# Altium schematic sheet 7.QMC5883L.SchDoc(7.QMC5883L)
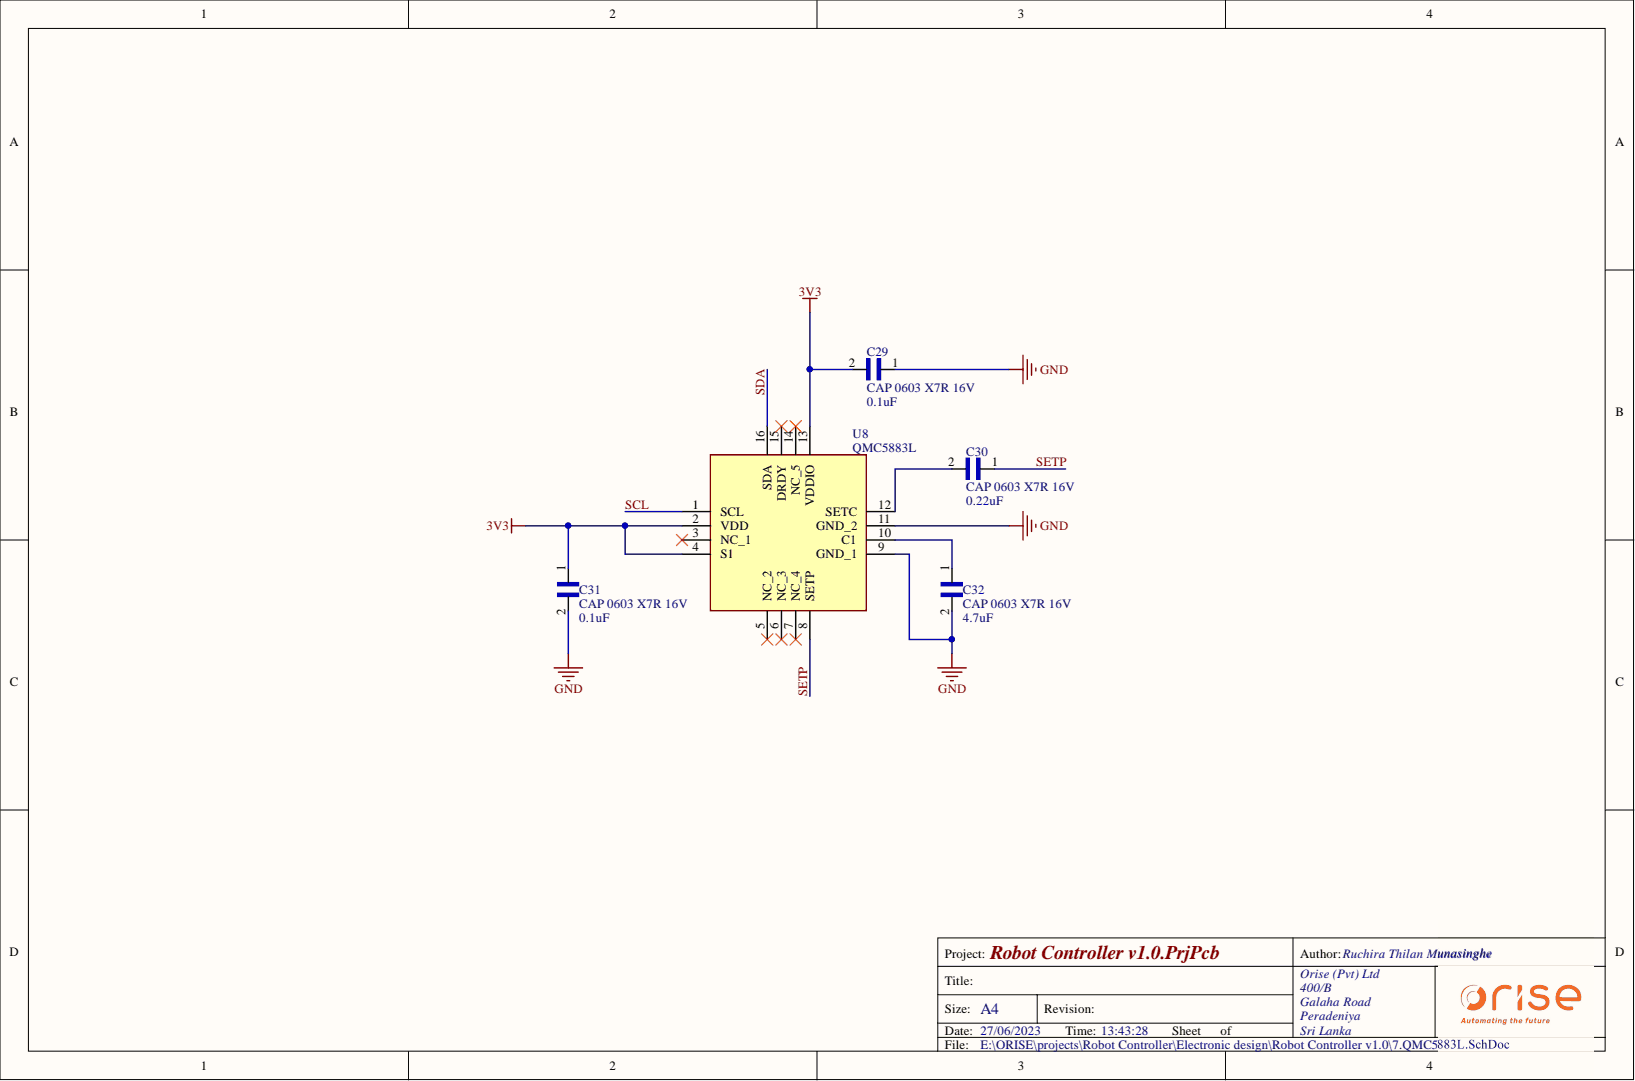
[diagram p0: 7.QMC5883L.SchDoc]

## Parts
C29  CAP 0603 X7R 16V  value 0.1uF  [CAP 0603]
C30  CAP 0603 X7R 16V  value 0.22uF  [CAP 0603]
C31  CAP 0603 X7R 16V  value 0.1uF  [CAP 0603]
C32  CAP 0603 X7R 16V  value 4.7uF  [CAP 0603]
U8  QMC5883L  [QMC5883L]

## Nets
3V3: C29.2 C31.1 U8.2(VDD) U8.4(S1) U8.13(VDDIO)
GND: C29.1 C31.2 C32.2 U8.9(GND_1) U8.11(GND_2)
SCL: U8.1(SCL)
SDA: U8.16(SDA)
SETP: C30.1 U8.8(SETP)
NetC30_2: C30.2 U8.12(SETC)
NetC32_1: C32.1 U8.10(C1)

Not connected: U8 3(NC_1) 5(NC_2) 6(NC_3) 7(NC_4) 14(NC_5) 15(DRDY)

## Library data of the parts
U8 = QMC5883L: Integrated Circuit; Manufacturer_Part_Number: HMC5883L; Manufacturer_Name: Honeywell; Library Name: Robot Controller v1.0.SchLib; Height: 0.9mm; Datasheet Link: http://www.farnell.com/datasheets/1683374.pdf; Component Kind: Standard
C29-C32 = CAP 0603 X7R 16V: Library Name: Robot Controller v1.0.SchLib; Description: CAP 0603 X7R 16V; Component Kind: Standard
